# Altium schematic sheet PWR.SchDoc
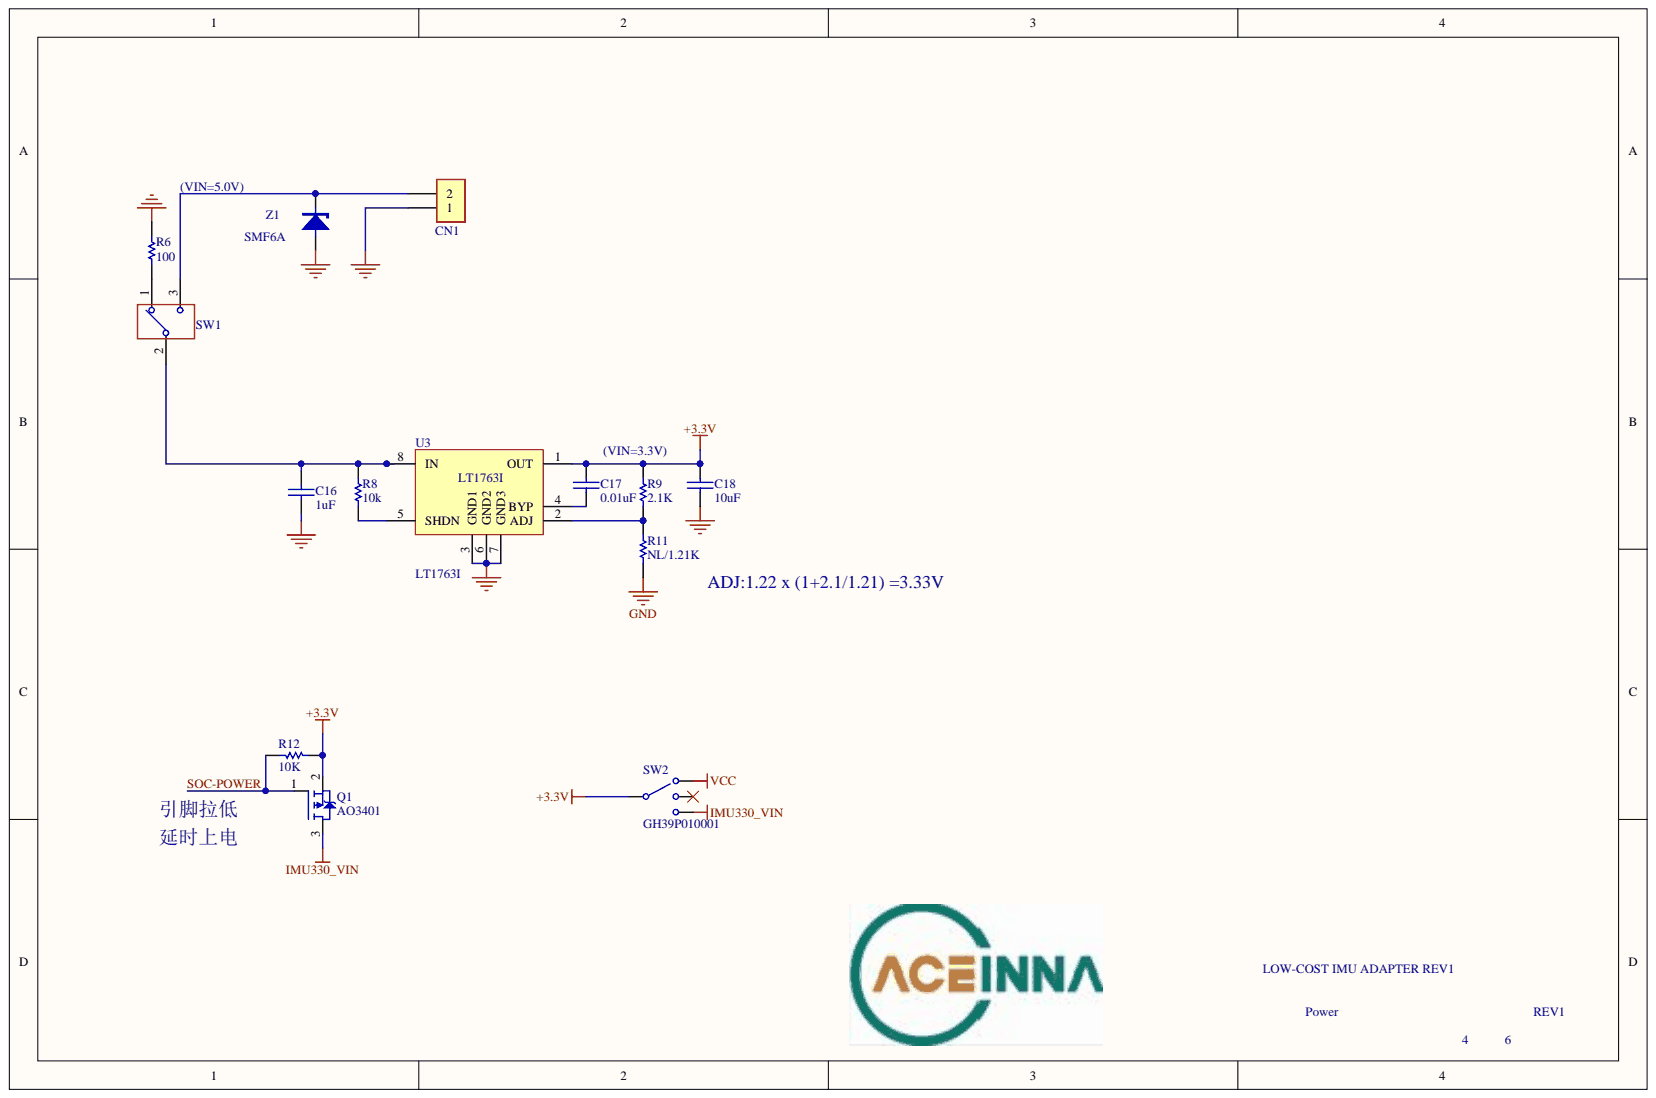
[diagram p0: PWR.SchDoc]

## Parts
C16  1uF  [LC-0603_C]
C17  0.01uF  [LC-0402_C]
C18  10uF  [LC-0603_C]
CN1  CON-2PIN-MOLEX  [KF2EDG-3.81MM-2P]  (value not drawn)
Q1  AO3401  [LC-SOT-23(SOT-23-3)]
R6  100  [LC-0603_R]
R8  10k  [LC-0402_R]
R9  2.1K  [LC-0402_R]
R11  NL/1.21K  [LC-0402_R]
R12  10K  [LC-0402_R]
SW1  GH36P010001  [GH39P010001]  (value not drawn)
SW2  GH39P010001  [GH39P010001]
U3  LT1763I  [LC-SOIC-8_150mil]
Z1  SMF6A  [LC-SOD-323F]

## Nets
+3.3V: C17.1 C18.1 Q1.2 R9.2 R12.1 SW2.2 U3.1(OUT)
GND: C16.2 C18.2 CN1.1 R6.2 R11.1 U3.3(GND1) U3.6(GND2) U3.7(GND3) Z1.1
IMU330_VIN: Q1.3 SW2.3
VCC: SW2.1
SOC-POWER: Q1.1 R12.2
NetC16_1: C16.1 R8.2 SW1.2 U3.8(IN)
NetC17_2: C17.2 U3.4(BYP)
NetCN1_2: CN1.2 SW1.3 Z1.2
NetR6_1: R6.1 SW1.1
NetR8_1: R8.1 U3.5(SHDN)
NetR9_1: R9.1 R11.2 U3.2(ADJ)

## Library data of the parts
C16 = CAP SMD 0402_2: SCAP, CER, 1uF, 6.3V, 10%, X5R, 0402, -55℃~85℃, RoHS; PRIMARY MANUFACTURER: TDK; PRIMARY MPN: C1005X5R1C105K; Component Kind: Standard; Library Name: 电容MLCC.SchLib; PRICE: 0.002$@1K
C17 = CAP SMD 0402_5: SCAP, CER, 0.01uF, 25V, 10%, X7R, 0402, -55℃~125℃, RoHS; PRIMARY MANUFACTURER: TDK; PRIMARY MPN: C1005X7R1E103K050BB; Component Kind: Standard; Library Name: 电容MLCC.SchLib; PRICE: 0.002$@1K
C18 = CAP SMD 0603_1: SCAP, CER, 10uF, 10V, 20%, X5R, 0603, -55℃~85℃, RoHS; PRIMARY MANUFACTURER: KEMET; PRIMARY MPN: C0402C225M8PACTU; Component Kind: Standard; Library Name: 电容MLCC.SchLib
CN1 = CON-2PIN-MOLEX: CONN, HDR, 2P, 2.5mm, STRAIGHT, RoHS; PRIMARY MANUFACTURER: MOLEX; Component Kind: Standard; Library Name: Connector.SchLib; PRIMARY MPN: 19-99-0986
Q1 = PNP mosfet: Component Kind: Standard; Library Name: General.SchLib
R6 R12 = RES1_4: RES,100 OHM,1/10W,5%,0603; PRIMARY MANUFACTURER: PANASONIC; PRIMARY MPN: ERJ-3GEY0R00V; PN: 4040-0003-01; Component Kind: Standard; Library Name: 电阻.SchLib
R8 = RES5: RES,10K OHM,1/16W,5%,0402; PRIMARY MANUFACTURER: PANASONIC; PRIMARY MPN: ERJ-3GEY0R00V; PN: 4040-0003-01; Component Kind: Standard; Library Name: 电阻.SchLib
R9 = RES4: RES,0 OHM,1/16W,5%,0402; PRIMARY MANUFACTURER: PANASONIC; PRIMARY MPN: ERJ-3GEY0R00V; PN: 4040-0003-01; Component Kind: Standard; Library Name: 电阻.SchLib
R11 = RES21: RES,1.21K OHM,1/16W,5%,0402; PRIMARY MANUFACTURER: PANASONIC; PRIMARY MPN: ERJ-3GEY0R00V; PN: 4040-0003-01; Component Kind: Standard; Library Name: 电阻.SchLib
SW1 = 500SSP1S1M2QEA: SW, SPDT, 5A@120VAC/28VDC, -30℃~ 85℃, RoHS; PRIMARY MANUFACTURER: E-SWITCH; Component Kind: Standard; Library Name: 连接器.SchLib; PRIMARY MPN: 500SSP1S1M2QEA
SW2 = 三挡开关: Component Kind: Standard; Library Name: General.SchLib
Z1 = SMAJ: TVS; Component Kind: Standard; Library Name: General.SchLib
U3 = LT1763I: Component Kind: Standard; Library Name: 380 OEM2 ADAPTER.SCHLIB
